# Altium schematic sheet Supply.SchDoc(Supply)
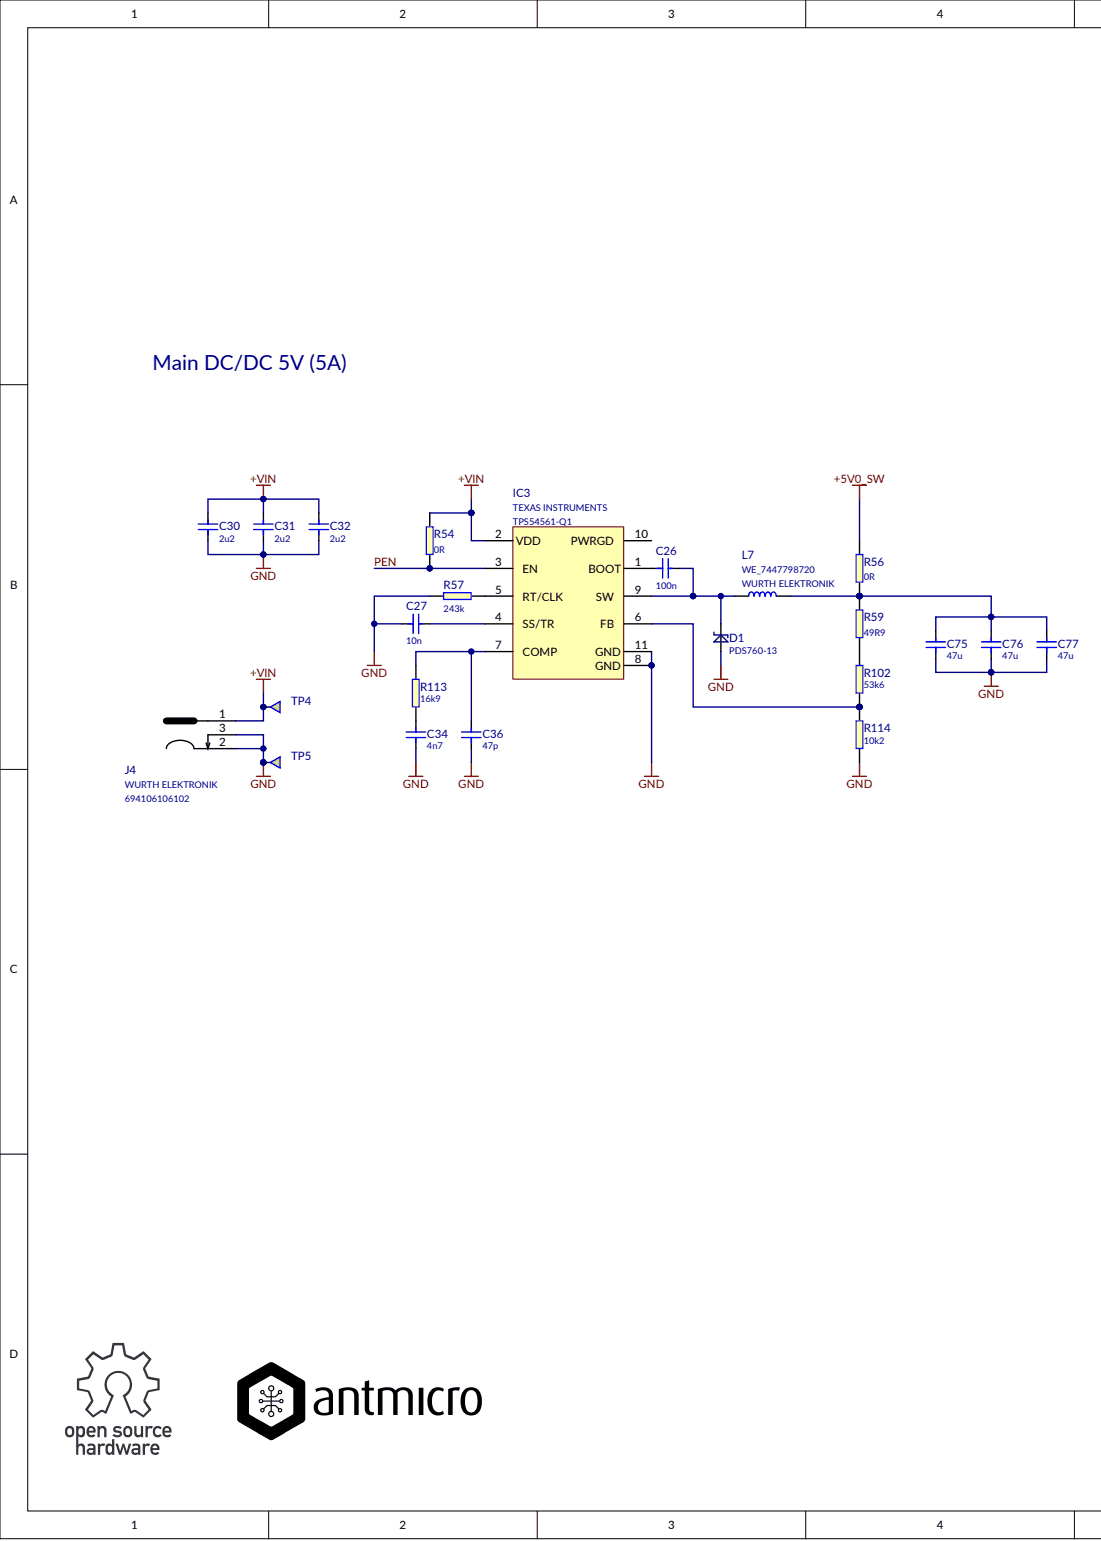
[diagram p0: Supply.SchDoc, left]
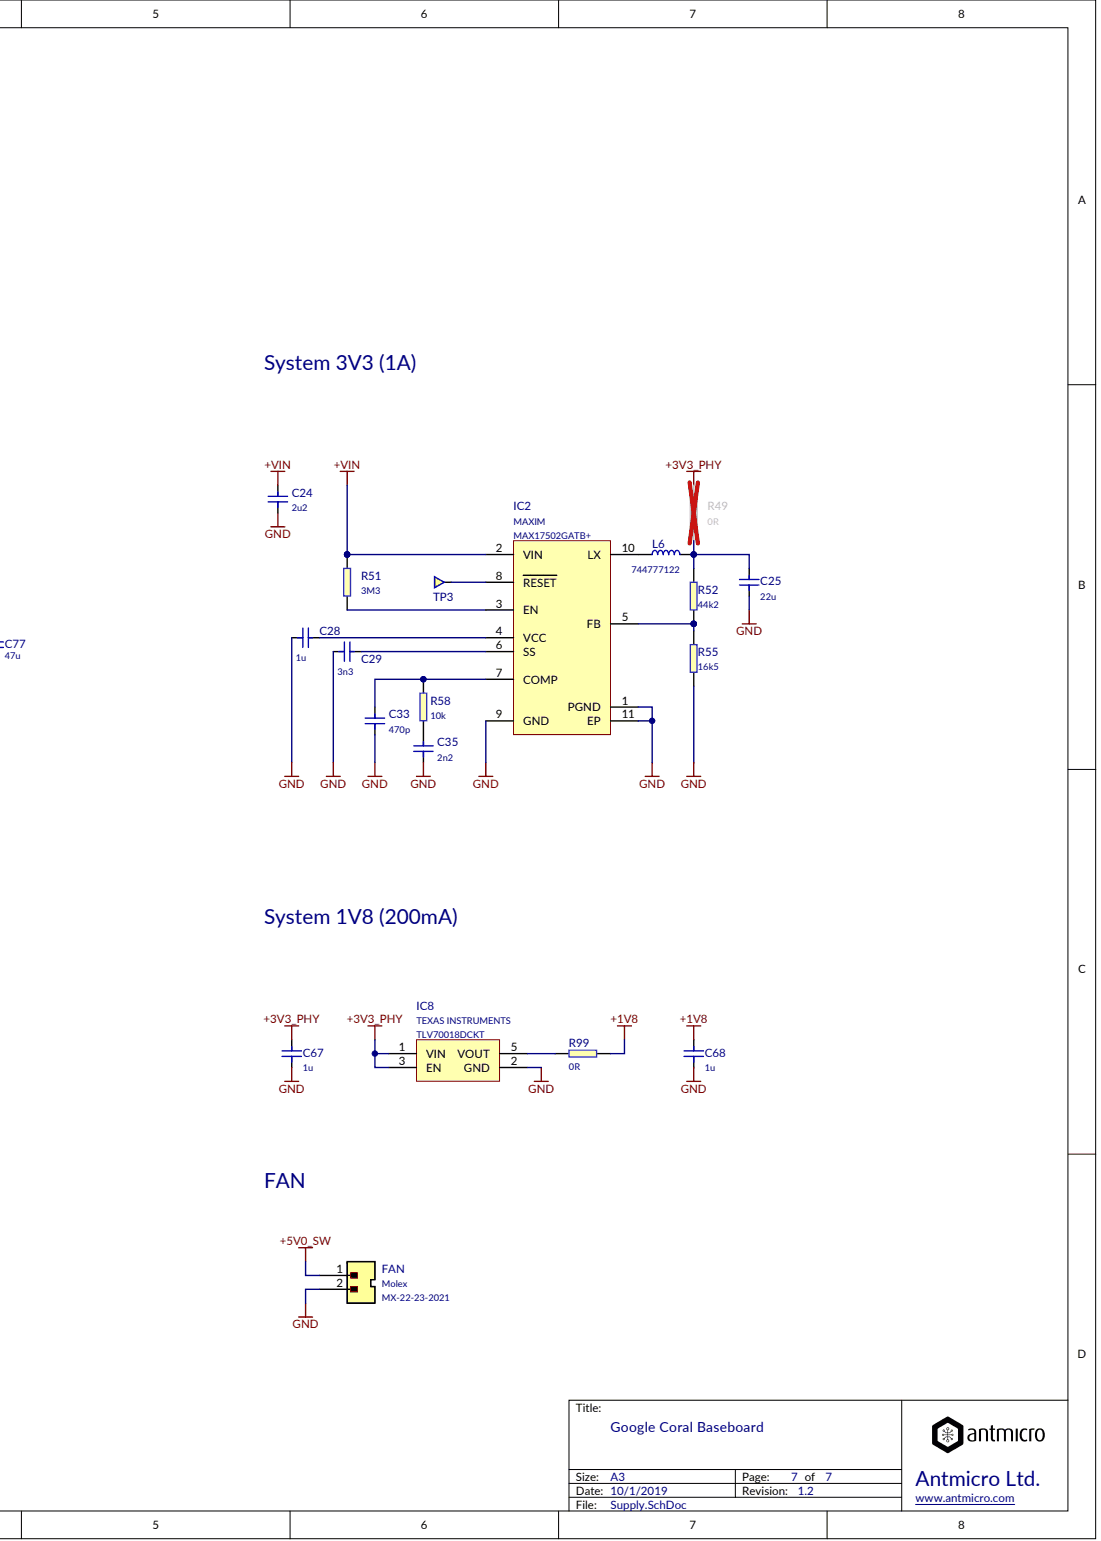
[diagram p1: Supply.SchDoc, right]

## Parts
C24  2u2
C25  22u
C26  100n
C27  10n
C28  1u
C29  3n3
C30  2u2
C31  2u2
C32  2u2
C33  470p
C34  4n7
C35  2n2
C36  47p
C67  1u
C68  1u
C75  47u
C76  47u
C77  47u
D1  PDS760-13
FAN  Manufacturer: Molex  (hidden comment: MX-22-23-2021)
IC2  Manufacturer: MAXIM  (hidden comment: MAX17502GATB+)
IC3  Manufacturer: TEXAS INSTRUMENTS  (hidden comment: TPS54561-Q1)
IC8  Manufacturer: TEXAS INSTRUMENTS  (hidden comment: TLV70018DCKT)
J4  Manufacturer: WURTH ELEKTRONIK  (hidden comment: 694106106102)
L6  744777122
L7  Manufacturer: WURTH ELEKTRONIK  (hidden comment: WE_7447798720)
R49  0R
R51  3M3
R52  44k2
R54  0R
R55  16k5
R56  0R
R57  243k
R58  10k
R59  49R9
R99  0R
R102  53k6
R113  16k9
R114  10k2
TP3  (no value)
TP4  Testpad  (value not drawn)
TP5  Testpad  (value not drawn)

## Nets
+1V8: C68.2 R99.2
+3V3_PHY: C67.2 IC8.1(VIN) IC8.3(EN) R49.2
+5V0_SW: FAN.1 R56.2
+VIN: C24.2 C30.2 C31.2 C32.2 IC2.2(VIN) IC3.2(VDD) J4.1 R51.2 R54.2 TP4.1
GND: C24.1 C25.1 C27.1 C28.1 C29.1 C30.1 C31.1 C32.1 C33.1 C34.1 C35.1 C36.1 C67.1 C68.1 C75.1 C76.1 C77.1 D1.A FAN.2 IC2.1(PGND) IC2.9(GND) IC2.11(EP) IC3.8(GND) IC3.11(GND) IC8.2(GND) J4.2 J4.3 R55.1 R57.2 R114.1 TP5.1
PEN: IC3.3(EN) R54.1
NetC25_2: C25.2 L6.2 R49.1 R52.2
NetC26_1: C26.1 IC3.1(BOOT)
NetC26_2: C26.2 D1.C IC3.9(SW) L7.1
NetC27_2: C27.2 IC3.4(SS/TR)
NetC28_2: C28.2 IC2.4(VCC)
NetC29_2: C29.2 IC2.6(SS)
NetC33_2: C33.2 IC2.7(COMP) R58.2
NetC34_2: C34.2 R113.1
NetC35_2: C35.2 R58.1
NetC36_2: C36.2 IC3.7(COMP) R113.2
NetC75_2: C75.2 C76.2 C77.2 L7.2 R56.1 R59.2
NetIC2_3: IC2.3(EN) R51.1
NetIC2_5: IC2.5(FB) R52.1 R55.2
NetIC2_8: IC2.8(RESET) TP3.1
NetIC2_10: IC2.10(LX) L6.1
NetIC3_5: IC3.5(RT/CLK) R57.1
NetIC3_6: IC3.6(FB) R102.1 R114.2
NetIC8_5: IC8.5(VOUT) R99.1
NetR59_1: R59.1 R102.2

Not connected: IC3 10(PWRGD)

## Library data of the parts
C24 = (no symbol name): PartNumber: C_2u2_1210; Value: 2u2
C25 = (no symbol name): PartNumber: C_22u_1210; Value: 22u
C26 = (no symbol name): PartNumber: C_100n_0603; Manufacturer: AVX; Value: 100n
C27 = (no symbol name): PartNumber: C_10n_0402; Manufacturer: AVX; Value: 10n
C28 C67 C68 = (no symbol name): PartNumber: C_1u_0402; Value: 1u
C29 = (no symbol name): PartNumber: C_3n3_0603; Value: 3n3
C30-C32 = (no symbol name): PartNumber: C_2u2_1206; Manufacturer: Kemet; Value: 2u2
C33 = (no symbol name): PartNumber: C_470p_0603; Value: 470p
C34 = (no symbol name): PartNumber: C_4n7_0402; Manufacturer: TDK; Value: 4n7
C35 = (no symbol name): PartNumber: C_2n2_0603; Value: 2n2
C36 = (no symbol name): PartNumber: C_47p_0402; Manufacturer: KEMET; Value: 47p
C75-C77 = (no symbol name): PartNumber: C_47u_0805; Manufacturer: KEMET; Value: 47u
D1 = (no symbol name): Manufacturer: DIODES INC.; PartNumber: PDS760-13; Value: PDS760-13
FAN = (no symbol name): PartNumber: 022232021 A-6373-02A222; Value: MX-22-23-2021
L6 = (no symbol name): Manufacturer: WURTH ELEKTRONIK; Value: 744777122; PartNumber: 744777122
R49 = (no symbol name): PartNumber: R_0R_0805; Value: 0R
R51 = (no symbol name): PartNumber: R_3M3_0402; Value: 3M3
R52 = (no symbol name): PartNumber: R_44k2_0402; Value: 44k2
R54 = (no symbol name): PartNumber: R_0R_0402; Value: 0R
R55 = (no symbol name): PartNumber: R_16k5_0402_0.1%; Value: 16k5
R56 = (no symbol name): PartNumber: R_0R_1206; Value: 0R
R57 = (no symbol name): PartNumber: R_243k_0402; Value: 243k
R58 = (no symbol name): PartNumber: R_10k_0402; Value: 10k
R59 = (no symbol name): PartNumber: R_49R9_0402; Value: 49R9
R99 = (no symbol name): PartNumber: R_0R_0603; Value: 0R
R102 = (no symbol name): PartNumber: R_53k6_0402; Manufacturer: TE CONNECTIVITY; Value: 53k6
R113 = (no symbol name): PartNumber: R_16k9_0402; Manufacturer: PANASONIC; Value: 16k9
R114 = (no symbol name): PartNumber: R_10k2_0402_0.1%; Manufacturer: TE CONECTIVITY; Value: 10k2
TP3 = (no symbol name): PartNumber: Testpad
IC2 IC3 IC8 J4 L7 TP4 TP5 = (no symbol name)
  IC2: Value: MAX17502GATB+; PartNumber: MAX17502GATB+
  IC3: PartNumber: TPS54561-Q1; Value: TPS54561-Q1
  IC8: PartNumber: TLV70018DCKT; Value: TLV70018DCKT
  J4: Value: 694106106102; PartNumber: 694106106102
  L7: PartNumber: WE_7447798720; Value: WE_7447798720
  TP4 TP5: PartNumber: Testpad
